# Altium schematic sheet Circuit Control.SchDoc("Circuit Control")
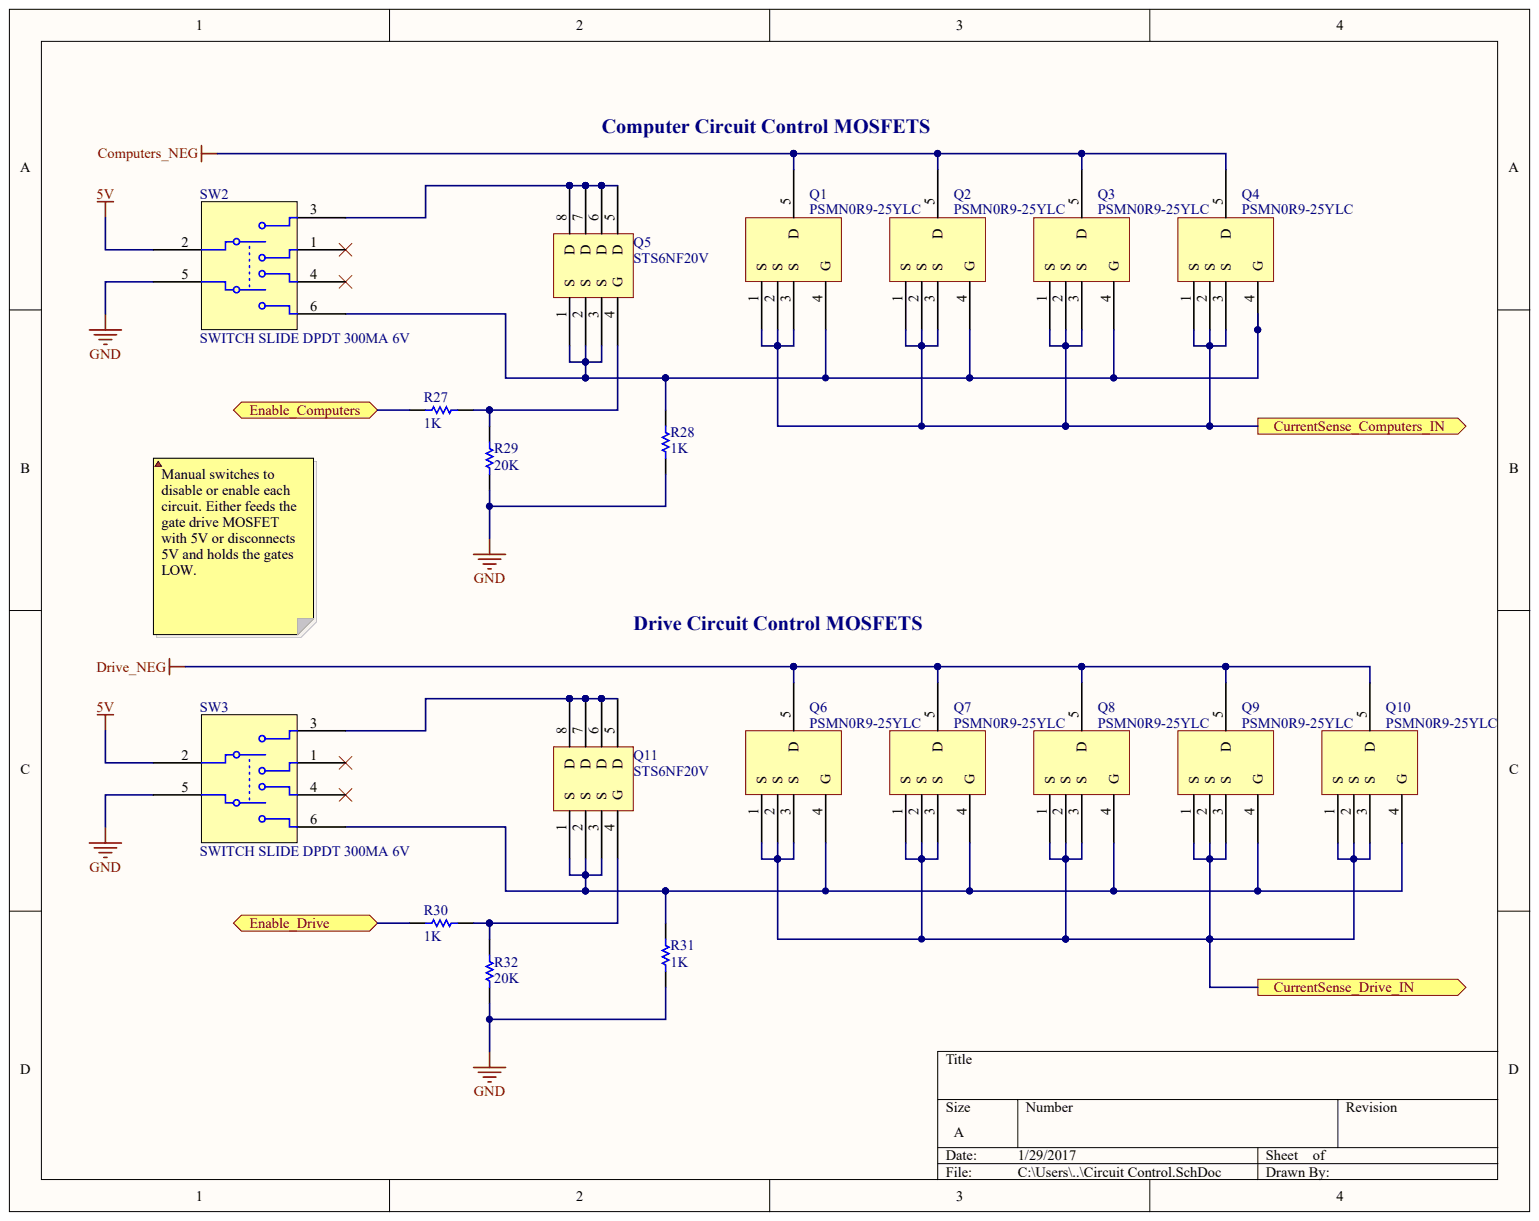
[diagram p0: Circuit Control.SchDoc]

## Parts
Q1  PSMN0R9-25YLC
Q2  PSMN0R9-25YLC
Q3  PSMN0R9-25YLC
Q4  PSMN0R9-25YLC
Q5  STS6NF20V
Q6  PSMN0R9-25YLC
Q7  PSMN0R9-25YLC
Q8  PSMN0R9-25YLC
Q9  PSMN0R9-25YLC
Q10  PSMN0R9-25YLC
Q11  STS6NF20V
R27  value 1K  (hidden comment: Res 0805)
R28  value 1K  (hidden comment: Res 0805)
R29  value 20K  (hidden comment: Res 0805)
R30  value 1K  (hidden comment: Res 0805)
R31  value 1K  (hidden comment: Res 0805)
R32  value 20K  (hidden comment: Res 0805)
SW2  SWITCH SLIDE DPDT 300MA 6V
SW3  SWITCH SLIDE DPDT 300MA 6V

## Ports
CURRENTSENSE_COMPUTERS_IN, CURRENTSENSE_DRIVE_IN, ENABLE_COMPUTERS, ENABLE_DRIVE

## Nets
5V: SW2.2 SW3.2
COMPUTERS_NEG: Q1.5(D) Q2.5(D) Q3.5(D) Q4.5(D)
CURRENTSENSE_COMPUTERS_IN: Q1.1(S) Q1.2(S) Q1.3(S) Q2.1(S) Q2.2(S) Q2.3(S) Q3.1(S) Q3.2(S) Q3.3(S) Q4.1(S) Q4.2(S) Q4.3(S) ; port CURRENTSENSE_COMPUTERS_IN
CURRENTSENSE_DRIVE_IN: Q6.1(S) Q6.2(S) Q6.3(S) Q7.1(S) Q7.2(S) Q7.3(S) Q8.1(S) Q8.2(S) Q8.3(S) Q9.1(S) Q9.2(S) Q9.3(S) Q10.1(S) Q10.2(S) Q10.3(S) ; port CURRENTSENSE_DRIVE_IN
DRIVE_NEG: Q6.5(D) Q7.5(D) Q8.5(D) Q9.5(D) Q10.5(D)
ENABLE_COMPUTERS: R27.2 ; port ENABLE_COMPUTERS
ENABLE_DRIVE: R30.2 ; port ENABLE_DRIVE
GND: R28.2 R29.2 R31.2 R32.2 SW2.5 SW3.5
NetQ1_4: Q1.4(G) Q2.4(G) Q3.4(G) Q4.4(G) Q5.1(S) Q5.2(S) Q5.3(S) R28.1 SW2.6
NetQ5_4: Q5.4(G) R27.1 R29.1
NetQ5_5: Q5.5(D) Q5.6(D) Q5.7(D) Q5.8(D) SW2.3
NetQ6_4: Q6.4(G) Q7.4(G) Q8.4(G) Q9.4(G) Q10.4(G) Q11.1(S) Q11.2(S) Q11.3(S) R31.1 SW3.6
NetQ11_4: Q11.4(G) R30.1 R32.1
NetQ11_5: Q11.5(D) Q11.6(D) Q11.7(D) Q11.8(D) SW3.3

Not connected: SW2 1 4; SW3 1 4

## Library data of the parts
Q1-Q11 R27-R32 SW2 SW3 = (no symbol name)
  Q5 Q11: URL: http://www.digikey.com/product-detail/en/stmicroelectronics/STS6NF20V/497-12679-1-ND/2864714
